# Altium schematic sheet RF_INOUT.SchDoc
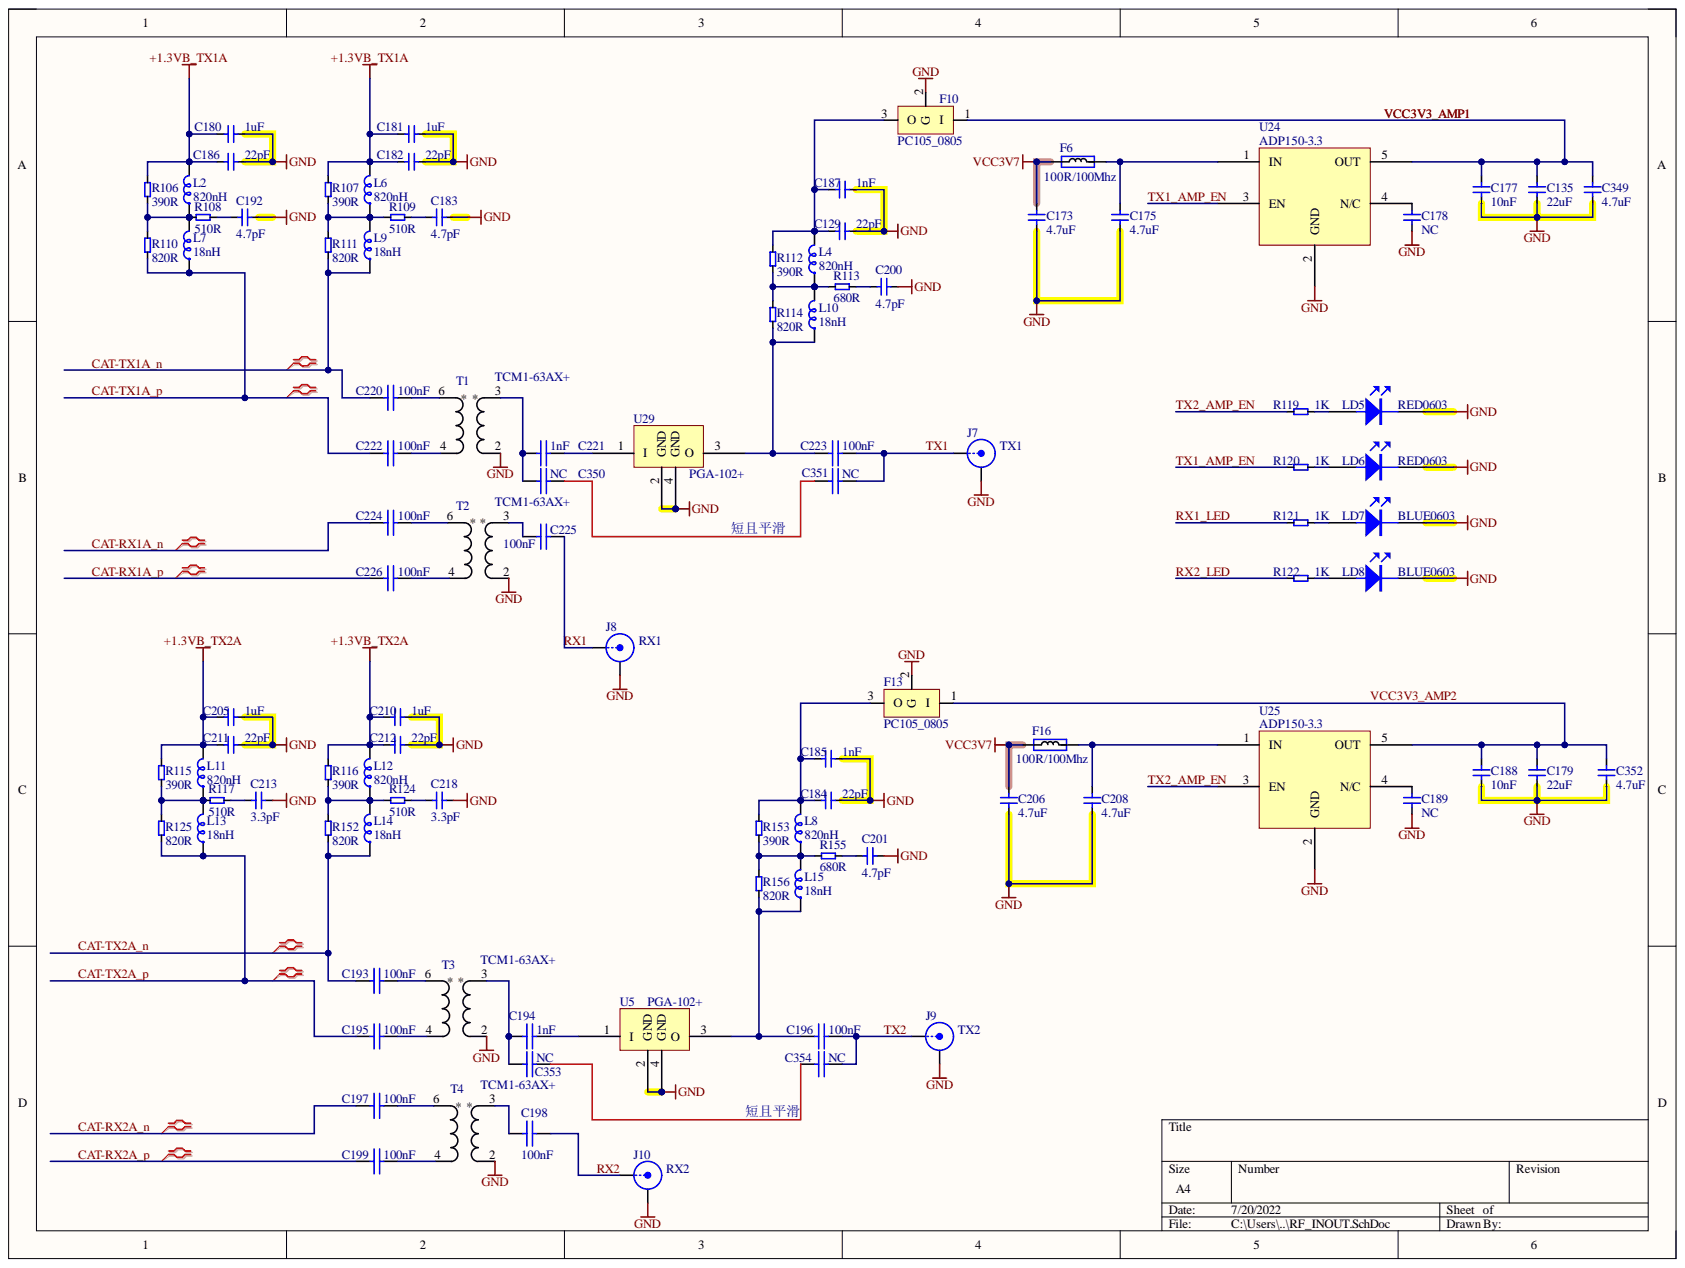
[diagram p0: RF_INOUT.SchDoc]

## Parts
C129  22pF  [C0201]
C135  22uF  [C0603]
C173  4.7uF  [C0402]
C175  4.7uF  [C0402]
C177  10nF  [C0201]
C178  NC  [C0402]
C179  22uF  [C0603]
C180  1uF  [C0402]
C181  1uF  [C0402]
C182  22pF  [C0201]
C183  4.7pF  [C0201]
C184  22pF  [C0201]
C185  1nF  [C0201]
C186  22pF  [C0201]
C187  1nF  [C0201]
C188  10nF  [C0201]
C189  NC  [C0402]
C192  4.7pF  [C0201]
C193  100nF  [C0201]
C194  1nF  [C0201]
C195  100nF  [C0201]
C196  100nF  [C0201]
C197  100nF  [C0201]
C198  100nF  [C0201]
C199  100nF  [C0201]
C200  4.7pF  [C0201]
C201  4.7pF  [C0201]
C205  1uF  [C0402]
C206  4.7uF  [C0402]
C208  4.7uF  [C0402]
C210  1uF  [C0402]
C211  22pF  [C0201]
C212  22pF  [C0201]
C213  3.3pF  [C0201]
C218  3.3pF  [C0201]
C220  100nF  [C0201]
C221  1nF  [C0201]
C222  100nF  [C0201]
C223  100nF  [C0201]
C224  100nF  [C0201]
C225  100nF  [C0201]
C226  100nF  [C0201]
C349  4.7uF  [C0402]
C350  NC  [C0201]
C351  NC  [C0201]
C352  4.7uF  [C0402]
C353  NC  [C0201]
C354  NC  [C0201]
F6  100R/100Mhz  [L0402]
F10  PC105_0805  [PC105_0805]
F13  PC105_0805  [PC105_0805]
F16  100R/100Mhz  [L0402]
J7  TX1  [RF_SMA]
J8  RX1  [RF_SMA]
J9  TX2  [RF_SMA]
J10  RX2  [RF_SMA]
L2  820nH  [L0402]
L4  820nH  [L0402]
L6  820nH  [L0402]
L7  18nH  [L0402]
L8  820nH  [L0402]
L9  18nH  [L0402]
L10  18nH  [L0402]
L11  820nH  [L0402]
L12  820nH  [L0402]
L13  18nH  [L0402]
L14  18nH  [L0402]
L15  18nH  [L0402]
LD5  RED0603  [LED0402]
LD6  RED0603  [LED0402]
LD7  BLUE0603  [LED0402]
LD8  BLUE0603  [LED0402]
R106  390R  [R0201]
R107  390R  [R0201]
R108  510R  [R0201]
R109  510R  [R0201]
R110  820R  [R0201]
R111  820R  [R0201]
R112  390R  [R0201]
R113  680R  [R0201]
R114  820R  [R0201]
R115  390R  [R0201]
R116  390R  [R0201]
R117  510R  [R0201]
R119  1K  [R0201]
R120  1K  [R0201]
R121  1K  [R0201]
R122  1K  [R0201]
R124  510R  [R0201]
R125  820R  [R0201]
R152  820R  [R0201]
R153  390R  [R0201]
R155  680R  [R0201]
R156  820R  [R0201]
T1  TCM1-63AX+  [TCM1_63AX+]
T2  TCM1-63AX+  [TCM1_63AX+]
T3  TCM1-63AX+  [TCM1_63AX+]
T4  TCM1-63AX+  [TCM1_63AX+]
U5  PGA-102+  [PGA-102]
U24  ADP150-3.3  [SOT23-5]
U25  ADP150-3.3  [SOT23-5]
U29  PGA-102+  [PGA-102]

## Nets
+1.3VB_TX1A: C180.2 C181.2 C182.1 C186.1 L2.2 L6.2 R106.1 R107.1
+1.3VB_TX2A: C205.2 C210.2 C211.1 C212.1 L11.2 L12.2 R115.1 R116.1
GND: C129.2 C135.1 C173.1 C175.2 C177.1 C178.1 C179.1 C180.1 C181.1 C182.2 C183.2 C184.2 C185.1 C186.2 C187.1 C188.1 C189.2 C192.2 C200.2 C201.2 C205.1 C206.1 C208.2 C210.1 C211.2 C212.2 C213.2 C218.2 C349.2 C352.2 F10.2 F13.2 J7.2 J8.2 J9.2 J10.2 LD5.1 LD6.1 LD7.1 LD8.1 T1.2 T2.2 T3.2 T4.2 U5.2(GND) U5.4(GND) U24.2(GND) U25.2(GND) U29.2(GND) U29.4(GND)
VCC3V7: C173.2 C206.2 F6.1 F16.1
CAT-RX1A_n: C224.2
CAT-RX1A_p: C226.2
CAT-RX2A_n: C197.2
CAT-RX2A_p: C199.2
CAT-TX1A_n: C220.2 L9.1 R111.2
CAT-TX1A_p: C222.2 L7.1 R110.2
CAT-TX2A_n: C193.2 L14.1 R152.2
CAT-TX2A_p: C195.2 L13.1 R125.2
RX1: C225.2 J8.1
RX1_LED: R121.2
RX2: C198.2 J10.1
RX2_LED: R122.2
TX1: C223.1 C351.1 J7.1
TX1_AMP_EN: R120.2 U24.3(EN)
TX2: C196.1 C354.1 J9.1
TX2_AMP_EN: R119.2 U25.3(EN)
VCC3V3_AMP1: C135.2 C177.2 C349.1 F10.1 U24.5(OUT)
VCC3V3_AMP2: C179.2 C188.2 C352.1 F13.1 U25.5(OUT)
NetC129_1: C129.1 C187.2 F10.3 L4.2 R112.1
NetC175_1: C175.1 F6.2 U24.1(IN)
NetC178_2: C178.2 U24.4(N/C)
NetC183_1: C183.1 R109.2
NetC184_1: C184.1 C185.2 F13.3 L8.2 R153.1
NetC189_1: C189.1 U25.4(N/C)
NetC192_1: C192.1 R108.2
NetC193_1: C193.1 T3.6
NetC194_1: C194.1 U5.1(I)
NetC194_2: C194.2 C353.2 T3.3(**)
NetC195_1: C195.1 T3.4
NetC196_2: C196.2 L15.1 R156.2 U5.3(O)
NetC197_1: C197.1 T4.6
NetC198_1: C198.1 T4.3(**)
NetC199_1: C199.1 T4.4
NetC200_1: C200.1 R113.2
NetC201_1: C201.1 R155.2
NetC208_1: C208.1 F16.2 U25.1(IN)
NetC213_1: C213.1 R117.2
NetC218_1: C218.1 R124.2
NetC220_1: C220.1 T1.6
NetC221_1: C221.1 U29.1(I)
NetC221_2: C221.2 C350.2 T1.3(**)
NetC222_1: C222.1 T1.4
NetC223_2: C223.2 L10.1 R114.2 U29.3(O)
NetC224_1: C224.1 T2.6
NetC225_1: C225.1 T2.3(**)
NetC226_1: C226.1 T2.4
NetC350_1: C350.1 C351.2
NetC353_1: C353.1 C354.2
NetL2_1: L2.1 L7.2 R106.2 R108.1 R110.1
NetL4_1: L4.1 L10.2 R112.2 R113.1 R114.1
NetL6_1: L6.1 L9.2 R107.2 R109.1 R111.1
NetL8_1: L8.1 L15.2 R153.2 R155.1 R156.1
NetL11_1: L11.1 L13.2 R115.2 R117.1 R125.1
NetL12_1: L12.1 L14.2 R116.2 R124.1 R152.1
NetLD5_2: LD5.2 R119.1
NetLD6_2: LD6.2 R120.1
NetLD7_2: LD7.2 R121.1
NetLD8_2: LD8.2 R122.1

## Library data of the parts
C129 C135 C173 C175 C177-C189 C192 C200 C201 C205 C206 C208 C210-C213 C218 C349 C352 = C: Capacitor; Component Kind: Standard; Library Name: 电容-SCH.LIB
C193-C199 C220-C226 C350 C351 C353 C354 = CAP: Capacitor; Component Kind: Standard
F6 F16 = Ferrite: Manufacturer: TDK Corporation; Manufacturer Part Number 1: MPZ2012S601AT000; Part Description: FERRITE BEAD 600 OHM 0805 1LN; Current Rating: 2A; DC Resistance (DCR): 100 mOhm Max; Filter Type: Differential Mode - Single; Impedance @ Frequency: 600 Ohm @ 100MHz; Value: 600R, 2A; Category: Filters; Component Kind: Standard; Height (Max): 0.041" (1.05mm); Mounting Type: Surface Mount; Package / Case: 0805 (2012 Metric); Packaging: Tape & Reel (TR); RoHS: RoHS Compliant; Size / Dimension: 0.079" L x 0.049" W (2.00mm x 1.25mm); Supplier 1: Digi-Key; Supplier Part Number 1: 445-2206-2-ND; Library Name: LimeMicroAltiumLib_schLib.SchLib
F10 F13 = PC105_0805: 10uF穿心电容0805; Component Kind: Standard; Library Name: b200mini.SchLib
J7-J10 = RF_IF_connector: CONN UMC RCPT STR 50 OHM SMD; Manufacturer: Molex, LLC; Manufacturer Part Number 1: 0734120110; Body Finish: Nickel; Body Material: Phosphor Bronze; Center Contact Material: Brass; Center Contact Plating: Gold; Connector Style: Ultra Miniature Coaxial; Connector Type: Jack, Male Pin; Contact Termination: Solder; Dielectric Material: Liquid Crystal Polymer (LCP); Frequency - Max: 6GHz; Impedance: 50 Ohm; Voltage Rating: 60V; Cable Group: -; Category: Connectors, Interconnects; Component Kind: Standard; Fastening Type: Snap-On; Features: -; Housing Color: Silver; Ingress Protection: -; Mounting Type: Surface Mount; Operating Temperature: -40°C ~ 90°C; Packaging: Cut Tape (CT); Part Description: CONN UMC RCPT STR 50 OHM SMD; RoHS: RoHS Compliant; Shield Termination: Solder; Supplier 1: Digi-Key; Supplier Part Number 1: WM5587CT-ND; Library Name: LimeMicroAltiumLib_schLib.SchLib
L2 L4 L6-L15 = INDUCTOR1_1: Inductor; Component Kind: Standard; Library Name: 常用.SchLib
LD5-LD8 = LED_12: Component Kind: Standard; Library Name: zc706_2_0.SCHLIB
R106-R117 R119-R122 R124 R125 R152 R153 R155 R156 = RES2: Component Kind: Standard; Library Name: 电阻-SCH.LIB
T1-T4 = Balun_v2: Manufacturer: Mini-circuits; Part Description: RF balun, TCM1-63AX+; Component Kind: Standard; Manufacturer Part Number 1: TCM1-63AX+; Supplier 1: Minicircuits; Supplier Part Number 1: TCM1-63AX+; Library Name: LimeMicroAltiumLib_schLib.SchLib
U24 U25 = ADP150_3.3: Component Kind: Standard; Library Name: Schlib1.SchLib
U5 U29 = PGA-102+: Component Kind: Standard; Library Name: b200mini.SchLib
